# Altium schematic sheet Sheet1.SchDoc(Sheet1)
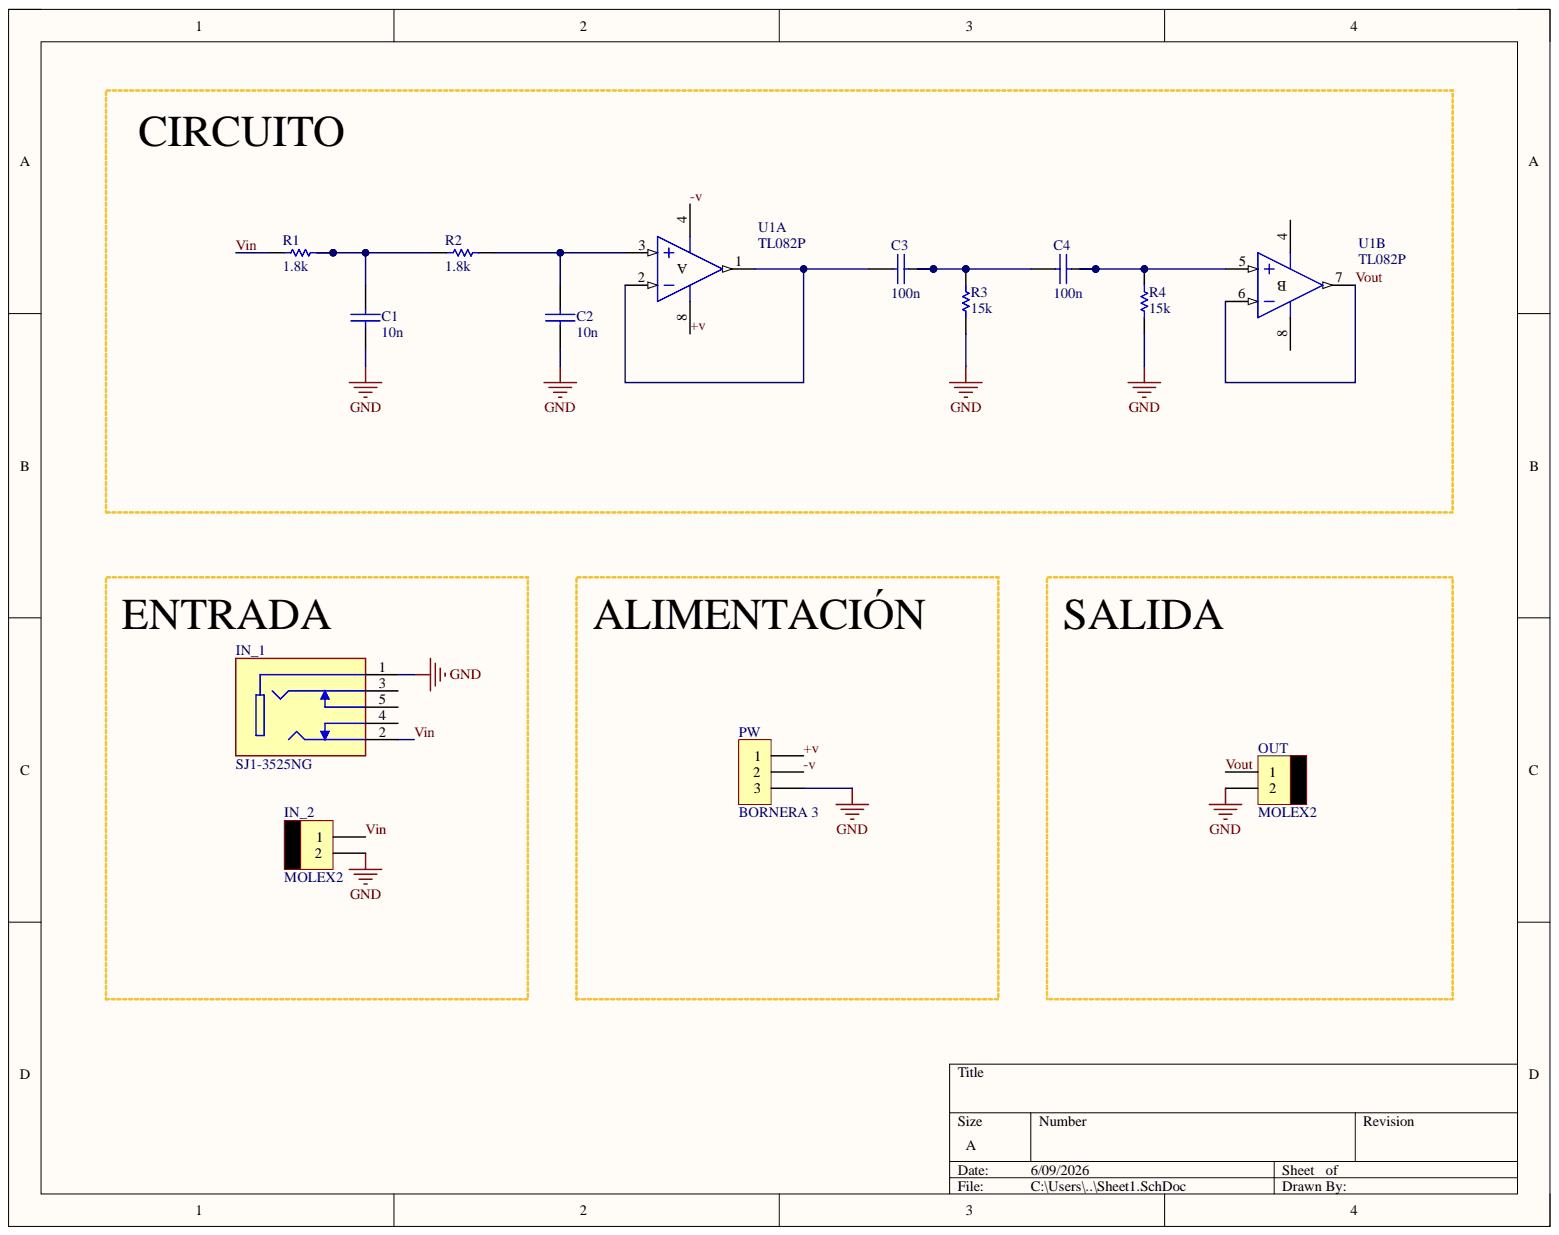
[diagram p0: Sheet1.SchDoc]

## Parts
C1  10n  [CAP200]
C2  10n  [CAP200]
C3  100n  [CAP200]
C4  100n  [CAP200]
IN_1  SJ1-3525NG  [CUI_SJ1-3525NG]
IN_2  MOLEX2  [MOLEX2]
OUT  MOLEX2  [MOLEX2]
PW  BORNERA 3  [BORNERA3]
R1  1.8k  [RES400]
R2  1.8k  [RES400]
R3  15k  [RES400]
R4  15k  [RES400]
U1  TL082P  [P008]  (parts A,B)

## Nets
GND: C1.2 C2.2 IN_1.1 IN_2.2 OUT.2 PW.3 R3.1 R4.1
+v: PW.1 U1.8
-v: PW.2 U1.4
Vin: IN_1.2 IN_2.1 R1.1
Vout: OUT.1 U1.6 U1.7
NetC1_1: C1.1 R1.2 R2.1
NetC2_1: C2.1 R2.2 U1.3
NetC3_1: C3.1 U1.1 U1.2
NetC3_2: C3.2 C4.1 R3.2
NetC4_2: C4.2 R4.2 U1.5

Not connected: IN_1 3 4 5

## Library data of the parts
C1-C4 = CAP: Component Kind: Standard; Library Name: LIB PIO IX.IntLib
IN_1 = SJ1-3525NG: 3.5 mm, Stereo, Right Angle, Through Hole, Isolated Ground, 3 Conductors, 2 Internal Switches, Audio Jack Connector; MF: Same Sky; Check_prices: https://www.snapeda.com/parts/SJ1-3525NG/Same+Sky/view-part/?ref=eda; Component Kind: Standard; Library Name: SJ1-3525NG.IntLib; MP: SJ1-3525NG; Package: None; SnapEDA_Link: https://www.snapeda.com/parts/SJ1-3525NG/Same+Sky/view-part/?ref=snap
R1-R4 = RES: Component Kind: Standard; Library Name: LIB PIO IX.IntLib
U1 = TL082P: JFET-Input Operational Amplifier; Code_JEDEC: MO-001-AN; Component Kind: Standard; ComponentLink1Description: Manufacturer Link; ComponentLink1URL: http://www.ti.com/; ComponentLink2Description: Datasheet; ComponentLink2URL: http://www-s.ti.com/sc/ds/tl082.pdf; DatasheetVersion: Feb-1999; LatestRevisionDate: 04-Mar-2005; LatestRevisionNote: Stylized 3D Model Added.; Library Name: TI Operational Amplifier.IntLib; PackageDescription: DIP; 8 Leads; Row Spacing 7.62 mm; Pitch 2.54 mm; PackageReference: P008; PackageVersion: Jun-1999; PCB3D: P008; Published: 16-Jan-2001; Simulation: TL082; Publisher: Altium Limited
IN_2 OUT = MOLEX2: Component Kind: Standard; Library Name: LIB PIO IX.IntLib
PW = BORNERA 3: Component Kind: Standard; Library Name: LIB PIO IX.IntLib
